# Altium schematic sheet USB.SchDoc(USB)
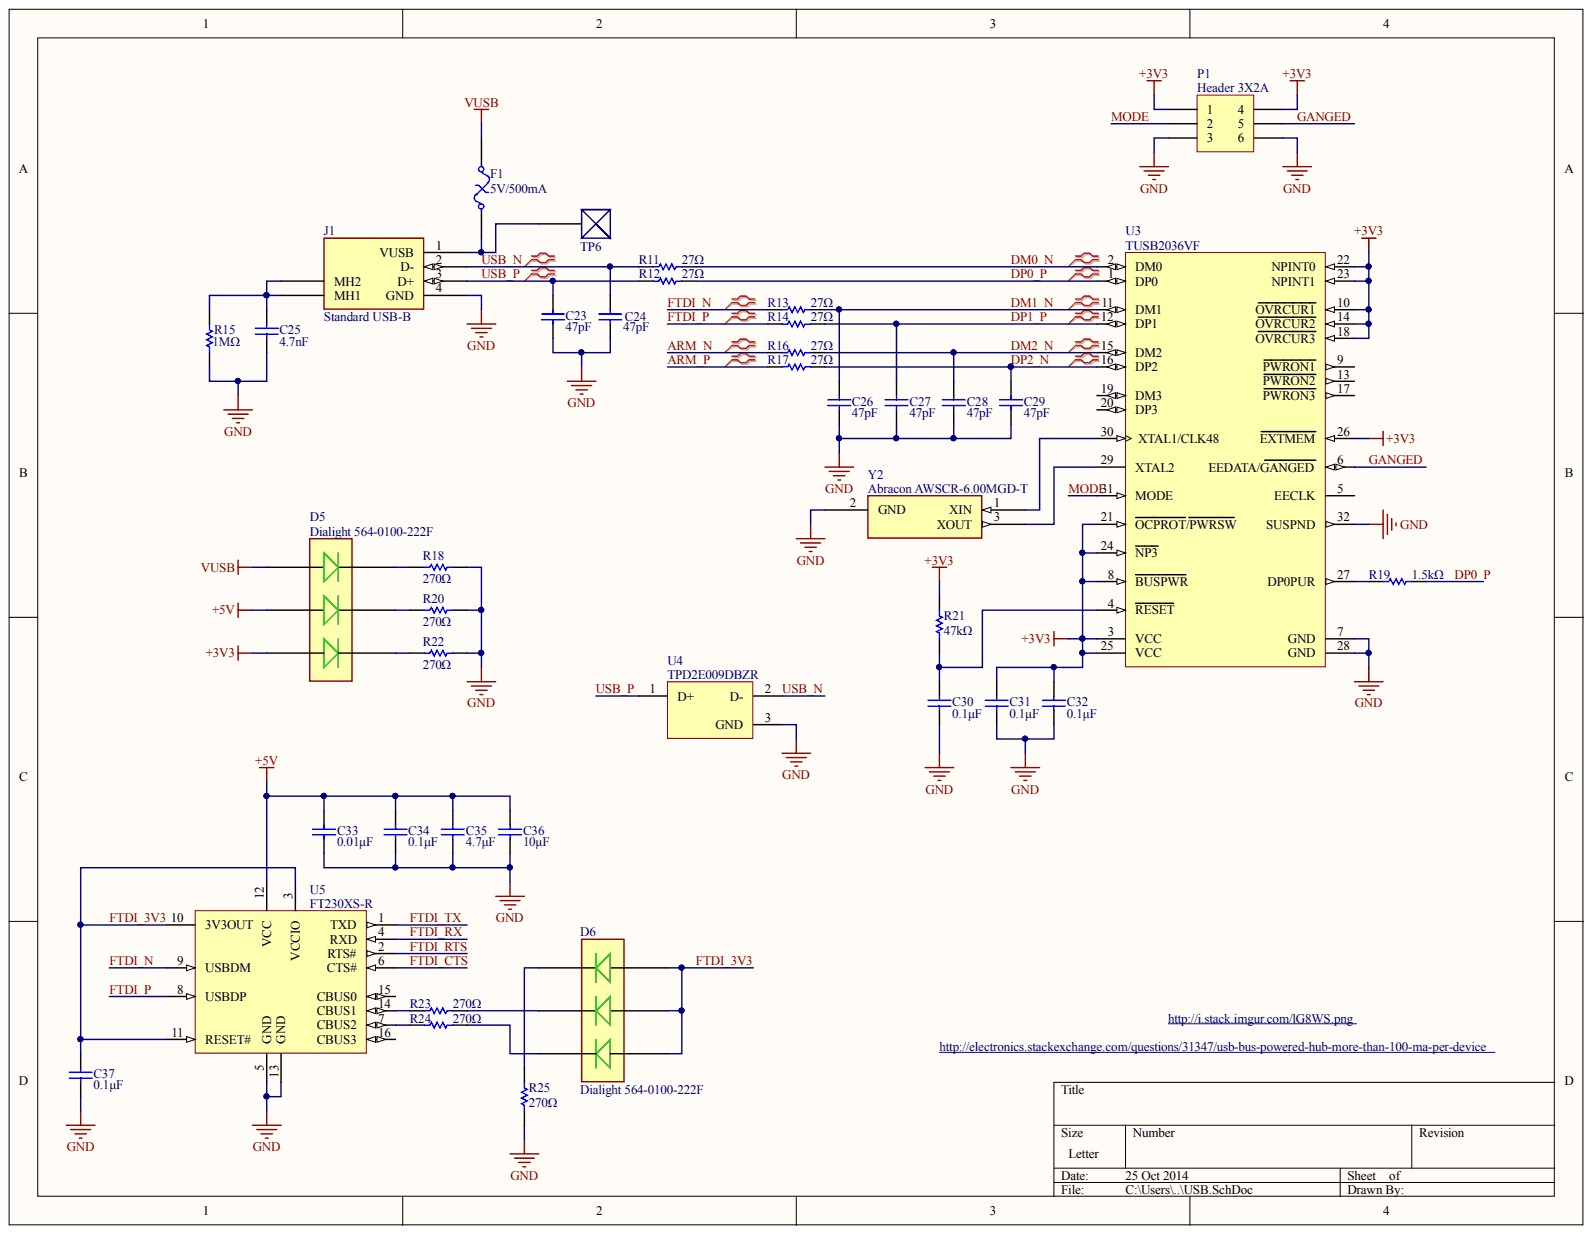
[diagram p0: USB.SchDoc]

## Parts
C23  value 47pF  [C0805]  (hidden comment: Cap)
C24  value 47pF  [C0805]  (hidden comment: Cap)
C25  value 4.7nF  [C0805]  (hidden comment: Cap)
C26  value 47pF  [C0805]  (hidden comment: Cap)
C27  value 47pF  [C0805]  (hidden comment: Cap)
C28  value 47pF  [C0805]  (hidden comment: Cap)
C29  value 47pF  [C0805]  (hidden comment: Cap)
C30  value 0.1μF  [C0805]  (hidden comment: Cap)
C31  value 0.1μF  [C0805]  (hidden comment: Cap)
C32  value 0.1μF  [C0805]  (hidden comment: Cap)
C33  value 0.01μF  [C0805]  (hidden comment: Cap)
C34  value 0.1μF  [C0805]  (hidden comment: Cap)
C35  value 4.7μF  [C0805]  (hidden comment: Cap)
C36  value 10μF  [C0805]  (hidden comment: Cap)
C37  value 0.1μF  [C0805]  (hidden comment: Cap)
D5  Dialight 564-0100-222F  [Dialight 564-0100-132F]
D6  Dialight 564-0100-222F  [Dialight 564-0100-132F]
F1  5V/500mA  [1812]
J1  Standard USB-B  [Standard USB Type-B]
P1  Header 3X2A  [HDR2X3_CEN]
R11  value 27Ω  [C0805]  (hidden comment: Res1)
R12  value 27Ω  [C0805]  (hidden comment: Res1)
R13  value 27Ω  [C0805]  (hidden comment: Res1)
R14  value 27Ω  [C0805]  (hidden comment: Res1)
R15  value 1MΩ  [C0805]  (hidden comment: Res1)
R16  value 27Ω  [C0805]  (hidden comment: Res1)
R17  value 27Ω  [C0805]  (hidden comment: Res1)
R18  value 270Ω  [C0805]  (hidden comment: Res1)
R19  value 1.5kΩ  [C0805]  (hidden comment: Res1)
R20  value 270Ω  [C0805]  (hidden comment: Res1)
R21  value 47kΩ  [C0805]  (hidden comment: Res1)
R22  value 270Ω  [C0805]  (hidden comment: Res1)
R23  value 270Ω  [C0805]  (hidden comment: Res1)
R24  value 270Ω  [C0805]  (hidden comment: Res1)
R25  value 270Ω  [C0805]  (hidden comment: Res1)
TP6  Testpoint  [TESTPOINT]  (value not drawn)
U3  TUSB2036VF  [VF32_N]
U4  TPD2E009DBZR  [DBZ3_N]
U5  FT230XS-R  [SSOP-16_N]
Y2  Abracon AWSCR-6.00MGD-T  [Abracom AWSCR-6.00MGD-T]

## Nets
+3V3: C31.2 C32.2 D5.2 P1.1 P1.4 R21.1 U3.3(VCC) U3.8(BUSPWR) U3.10(OVRCUR1) U3.14(OVRCUR2) U3.18(OVRCUR3) U3.21(OCPROT/PWRSW) U3.22(NPINT0) U3.23(NPINT1) U3.24(NP3) U3.25(VCC) U3.26(EXTMEM)
+5V: C33.2 C34.2 C35.2 C36.2 D5.4 U5.12(VCC)
GND: C23.1 C24.1 C25.1 C26.1 C27.1 C28.1 C29.1 C30.1 C31.1 C32.1 C33.1 C34.1 C35.1 C36.1 C37.1 J1.4(GND) P1.3 P1.6 R15.1 R18.1 R20.1 R22.1 R25.2 U3.7(GND) U3.28(GND) U3.32(SUSPND) U4.3 U5.5(GND) U5.13(GND) Y2.2
VUSB: D5.6 F1.2
ARM_N: R16.2
ARM_P: R17.2
DM0_N: R11.1 U3.2(DM0)
DM1_N: C26.2 R13.1 U3.11(DM1)
DM2_N: C28.2 R16.1 U3.15(DM2)
DP0_P: R12.1 R19.1 U3.1(DP0)
DP1_P: C27.2 R14.1 U3.12(DP1)
DP2_N: C29.2 R17.1 U3.16(DP2)
FTDI_3V3: C37.2 D6.2 D6.4 D6.6 U5.3(VCCIO) U5.10(3V3OUT) U5.11(RESET#)
FTDI_CTS: U5.6(CTS#)
FTDI_N: R13.2 U5.9(USBDM)
FTDI_P: R14.2 U5.8(USBDP)
FTDI_RTS: U5.2(RTS#)
FTDI_RX: U5.4(RXD)
FTDI_TX: U5.1(TXD)
GANGED: P1.5 U3.6(EEDATA/GANGED)
MODE: P1.2 U3.31(MODE)
USB_N: C24.2 J1.2(D-) R11.2 U4.2
USB_P: C23.2 J1.3(D+) R12.2 U4.1
NetC25_2: C25.2 J1.MH1 J1.MH2 R15.2
NetC30_2: C30.2 R21.2 U3.4(RESET)
NetD5_1: D5.1 R22.2
NetD5_3: D5.3 R20.2
NetD5_5: D5.5 R18.2
NetD6_1: D6.1 R24.1
NetD6_3: D6.3 R23.1
NetD6_5: D6.5 R25.1
NetF1_1: F1.1 J1.1(VUSB) TP6.1
NetR19_2: R19.2 U3.27(DP0PUR)
NetR23_2: R23.2 U5.14(CBUS1)
NetR24_2: R24.2 U5.7(CBUS2)
NetU3_29: U3.29(XTAL2) Y2.3
NetU3_30: U3.30(XTAL1/CLK48) Y2.1

Not connected: U3 5(EECLK) 9(PWRON1) 13(PWRON2) 17(PWRON3) 19(DM3) 20(DP3); U5 15(CBUS0) 16(CBUS3)

## Library data of the parts
C23-C37 = Cap: Capacitor; Component Kind: Standard; LatestRevisionDate: 17-Jul-2002; LatestRevisionNote: Re-released for DXP Platform.; Library Name: Miscellaneous Devices.IntLib; PackageDescription: Radial Cap, Thru-Hole; 2 Leads; 0.3 in Pin Spacing; PackageReference: RAD-0.3; Published: 8-Jun-2000; Signal Integrity: Cap; Simulation: CAP; Publisher: Altium Limited
D5 D6 = Dialight 564-0100-222F: LED 3HI 3MM GREEN PC MNT; Component Kind: Standard; Library Name: TS-Schematic.SchLib
F1 = Fuse Thermal: Thermal Fuse; Component Kind: Standard; LatestRevisionDate: 17-Jul-2002; LatestRevisionNote: Re-released for DXP Platform.; Library Name: Miscellaneous Devices.IntLib; PackageDescription: Thru-Hole; 2 Leads; PackageReference: PIN-W2/E2.8; Published: 24-Mar-1999; Publisher: Altium Limited
J1 = Standard USB-B: CONN USB RECEPT R/A TYPE B 4POS; Component Kind: Standard; Library Name: TS-Schematic.SchLib
P1 = Header 3X2A: Header, 3-Pin, Dual row; Component Kind: Standard; LatestRevisionDate: 17-Jul-2002; LatestRevisionNote: Re-released for DXP Platform.; Library Name: Miscellaneous Connectors.IntLib; Signal Integrity: Connector; Publisher: Altium Limited
R11-R25 = Res1: Resistor; Component Kind: Standard; LatestRevisionDate: 17-Jul-2002; LatestRevisionNote: Re-released for DXP Platform.; Library Name: Miscellaneous Devices.IntLib; PackageDescription: Axial Device, Thru-Hole; 2 Leads; 0.3 in Pin Spacing; PackageReference: AXIAL-0.3; Published: 8-Jun-2000; Signal Integrity: Res; Simulation: RESISTOR; Publisher: Altium Limited
U3 = TUSB2036VF: 2 / 3-Port Hub for USB with Optional Serial EEPROM Interface, 3.3V, 0 to 70 degC, 32-Pin LQFP (VF), Green (RoHS & no Sb/Br); Manufacturer: Texas Instruments; SubFamily: USB Hub Controller; Supply Voltage(s)(V): 3.3; USB Full Speed: True; USB Speed: Full; Component Kind: Standard; ComponentLink1Description: Datasheet; ComponentLink1URL: http://www.ti.com/general/docs/lit/getliterature.tsp?genericPartNumber=TUSB2036&fileType=pdf; ComponentLink2Description: Package Specification; ComponentLink2URL: http://www.ti.com/litv/pdf/mtqf002b; DatasheetVersion: SLLS372E; Eco-Plan: Green (RoHS & no Sb/Br); Integrated MCU Architecture: N/A; Library Name: Texas Instruments Interface USB.IntLib; Mounting Technology: Surface Mount; PackageDescription: 32-Pin Plastic Quad Flatpack, 7 x 7 mm Body, 0.8 mm Pitch; PackageReference: VF32; PackageVersion: revD, Apr-2000; PartNumber: TUSB2036VF
U4 = TPD2E009DBZR: ESD Protection Array for High-Speed Data Interfaces, 2 Channels, -40 to +85 degC, 3-pin SOT-23 (DBZ), Green (RoHS & no Sb/Br); Manufacturer: Texas Instruments; Breakdown Voltage(Min)(V): 9; Differential Capacitance(pF): 0.01; IEC 61000-4-2 Air-Gap(+/- kV): 8; IO Capacitance(Typ)(pF): 0.7; IO Leakage Current(nA): 10; Serial ATA / eSATA: TRUE; SubFamily: ESD Solution; Code_JEDEC: TO-236-AB; Component Kind: Standard; ComponentLink1Description: Datasheet; ComponentLink1URL: http://www.ti.com/general/docs/lit/getliterature.tsp?genericPartNumber=TPD2E009&fileType=pdf; ComponentLink2Description: URL Link1; ComponentLink2URL: SamplesURL:http://www.ti.com/product/tpd2e009#samples; DatasheetVersion: SLVS953A; Eco-Plan: Green (RoHS & no Sb/Br); IEC 61000-4-2 Contact(+/- kV): 8; Library Name: TI Interface ESD EMI Protection.IntLib; Mounting Technology: Surface Mount; PackageDescription: 3-Pin Plastic Small-Outline Package, 0.95 mm Pitch, 2.4 mm Lead Span; PackageReference: DBZ3; PackageVersion: revB, Apr-2005; PartNumber: TPD2E009DBZR; Supplier 1: Digi-Key; Supplier 2: Digi-Key; Supplier 3: Digi-Key; Supplier 4: Farnell; Supplier 5: Farnell; Supplier 6: Newark; Supplier 7: Mouser; Supplier Part Number 1: 296-24657-2-ND; Supplier Part Number 2: 296-24657-1-ND; Supplier Part Number 3: 296-24657-6-ND; Supplier Part Number 4: 1755715RL; Supplier Part Number 5: 1755715; Supplier Part Number 6: 14R9549; Supplier Part Number 7: 595-TPD2E009DBZR
U5 = FT230XS-R: USB to Basic UART Interface Chip, UHCI/OHCI/EHCI Compatible, USB 2.0 Compatible, -40 to +85 degC, 16-Pin SSOP, Pb-Free, Tape and Reel; Manufacturer: FTDI; Code_JEDEC: MO-137-AB; Component Kind: Standard; ComponentLink1Description: Manufacturer URL; ComponentLink1URL: http://www.ftdichip.com/; ComponentLink2Description: Datasheet; ComponentLink2URL: http://www.ftdichip.com/Support/Documents/DataSheets/ICs/DS_FT230X.pdf; ComponentLink3Description: FT230X Product Page; ComponentLink3URL: www.ftdichip.com/Products/ICs/FT230X.html; DatasheetVersion: ver 1.2, Feb-2013; Library Name: FTDI FT-X Series.IntLib; PackageDescription: 16-Pin Small Outline Package, 8.6 x 3.9 mm Body, 0.635 mm Pitch; PackageReference: SSOP-16; PackageVersion: Feb-13; PartNumber: FT230XS-R
Y2 = Abracon AWSCR-6.00MGD-T: RESONATOR CER 6.00MHZ W/CAP SMD; Component Kind: Standard; Library Name: TS-Schematic.SchLib
TP6 = Testpoint: Component Kind: Standard; Library Name: TS-Schematic.SchLib
